# Altium schematic sheet analog.SchDoc
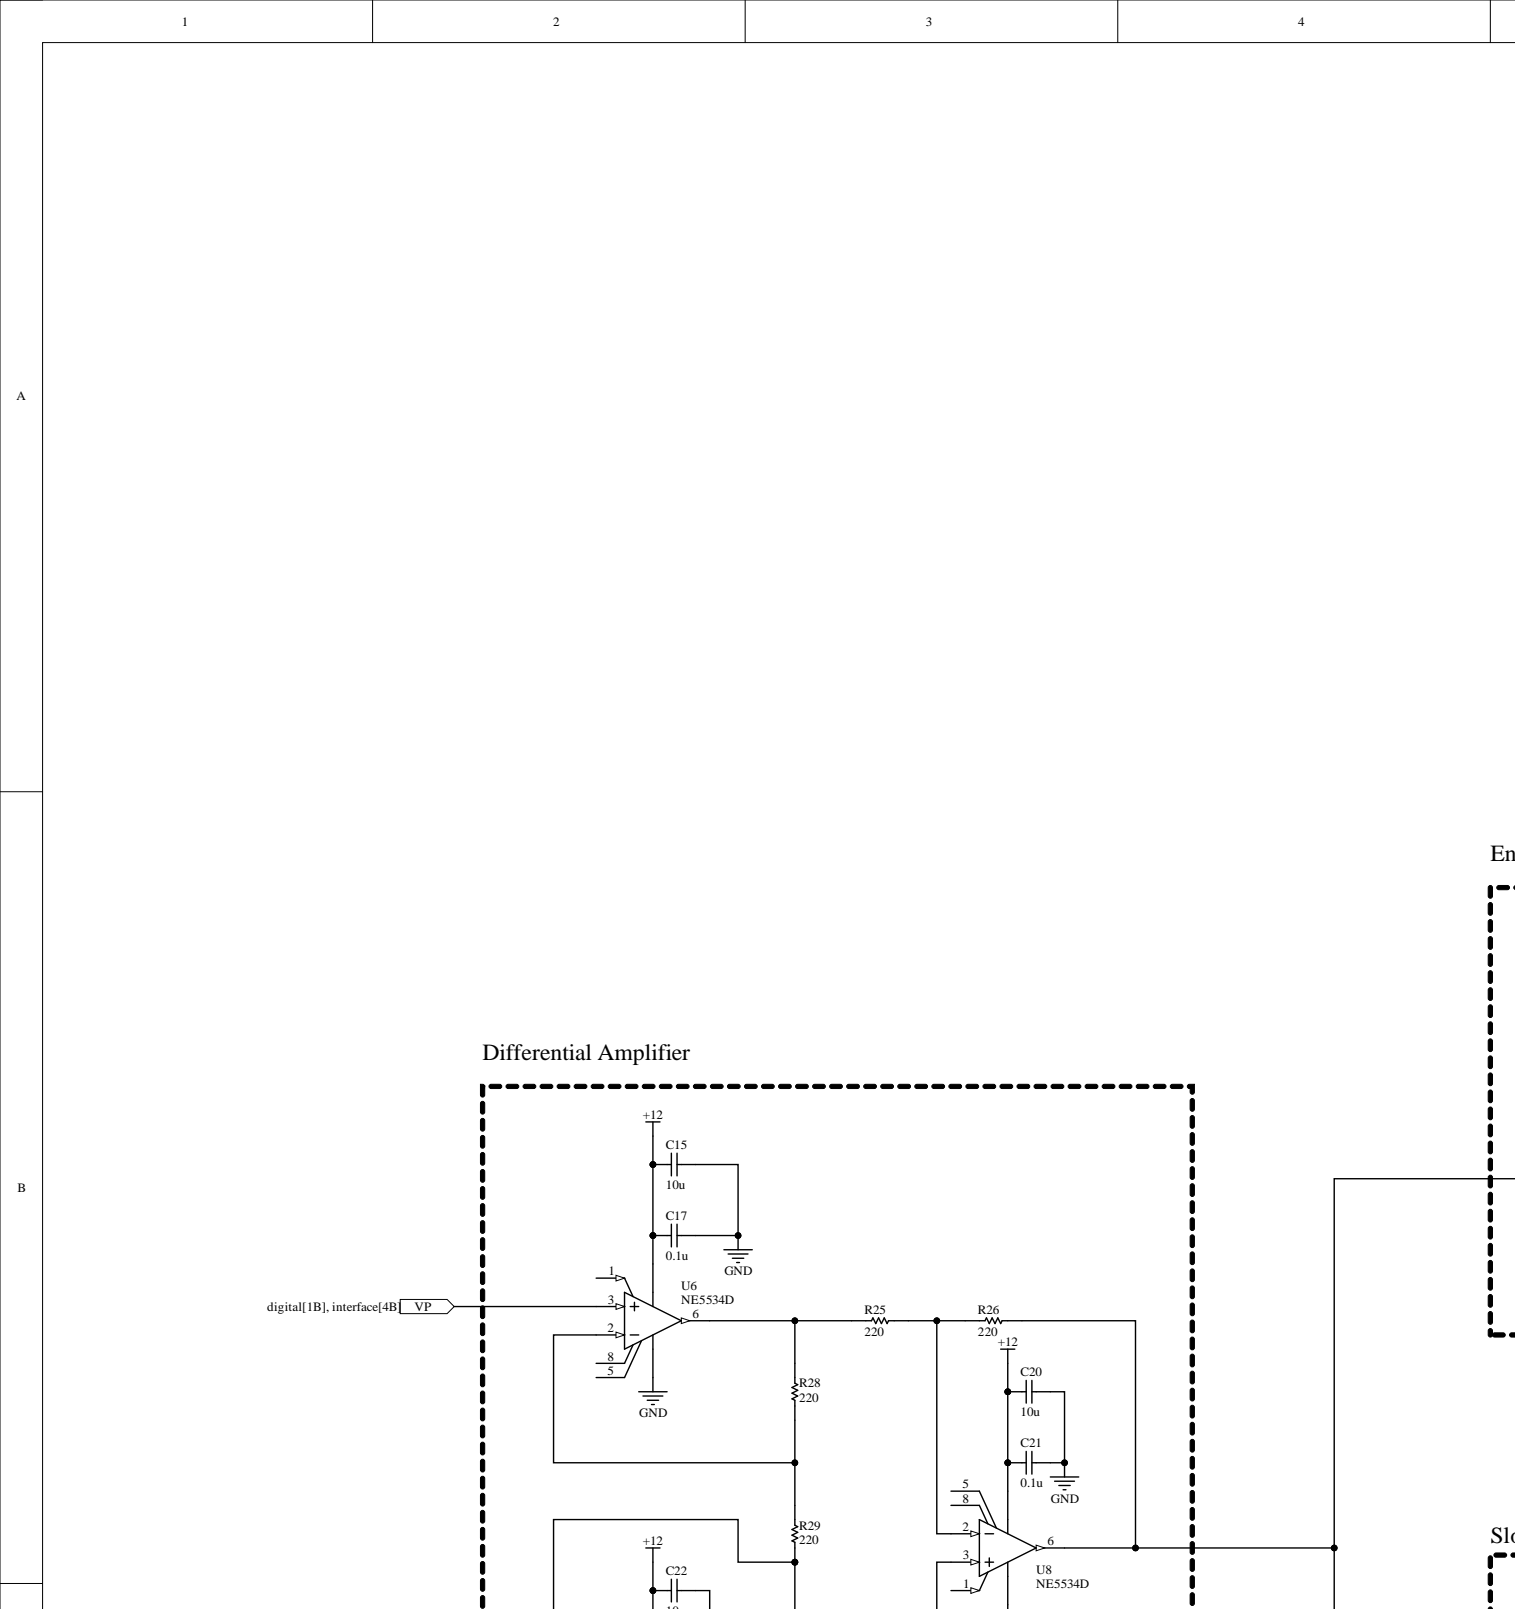
[diagram p0: analog.SchDoc, top left]
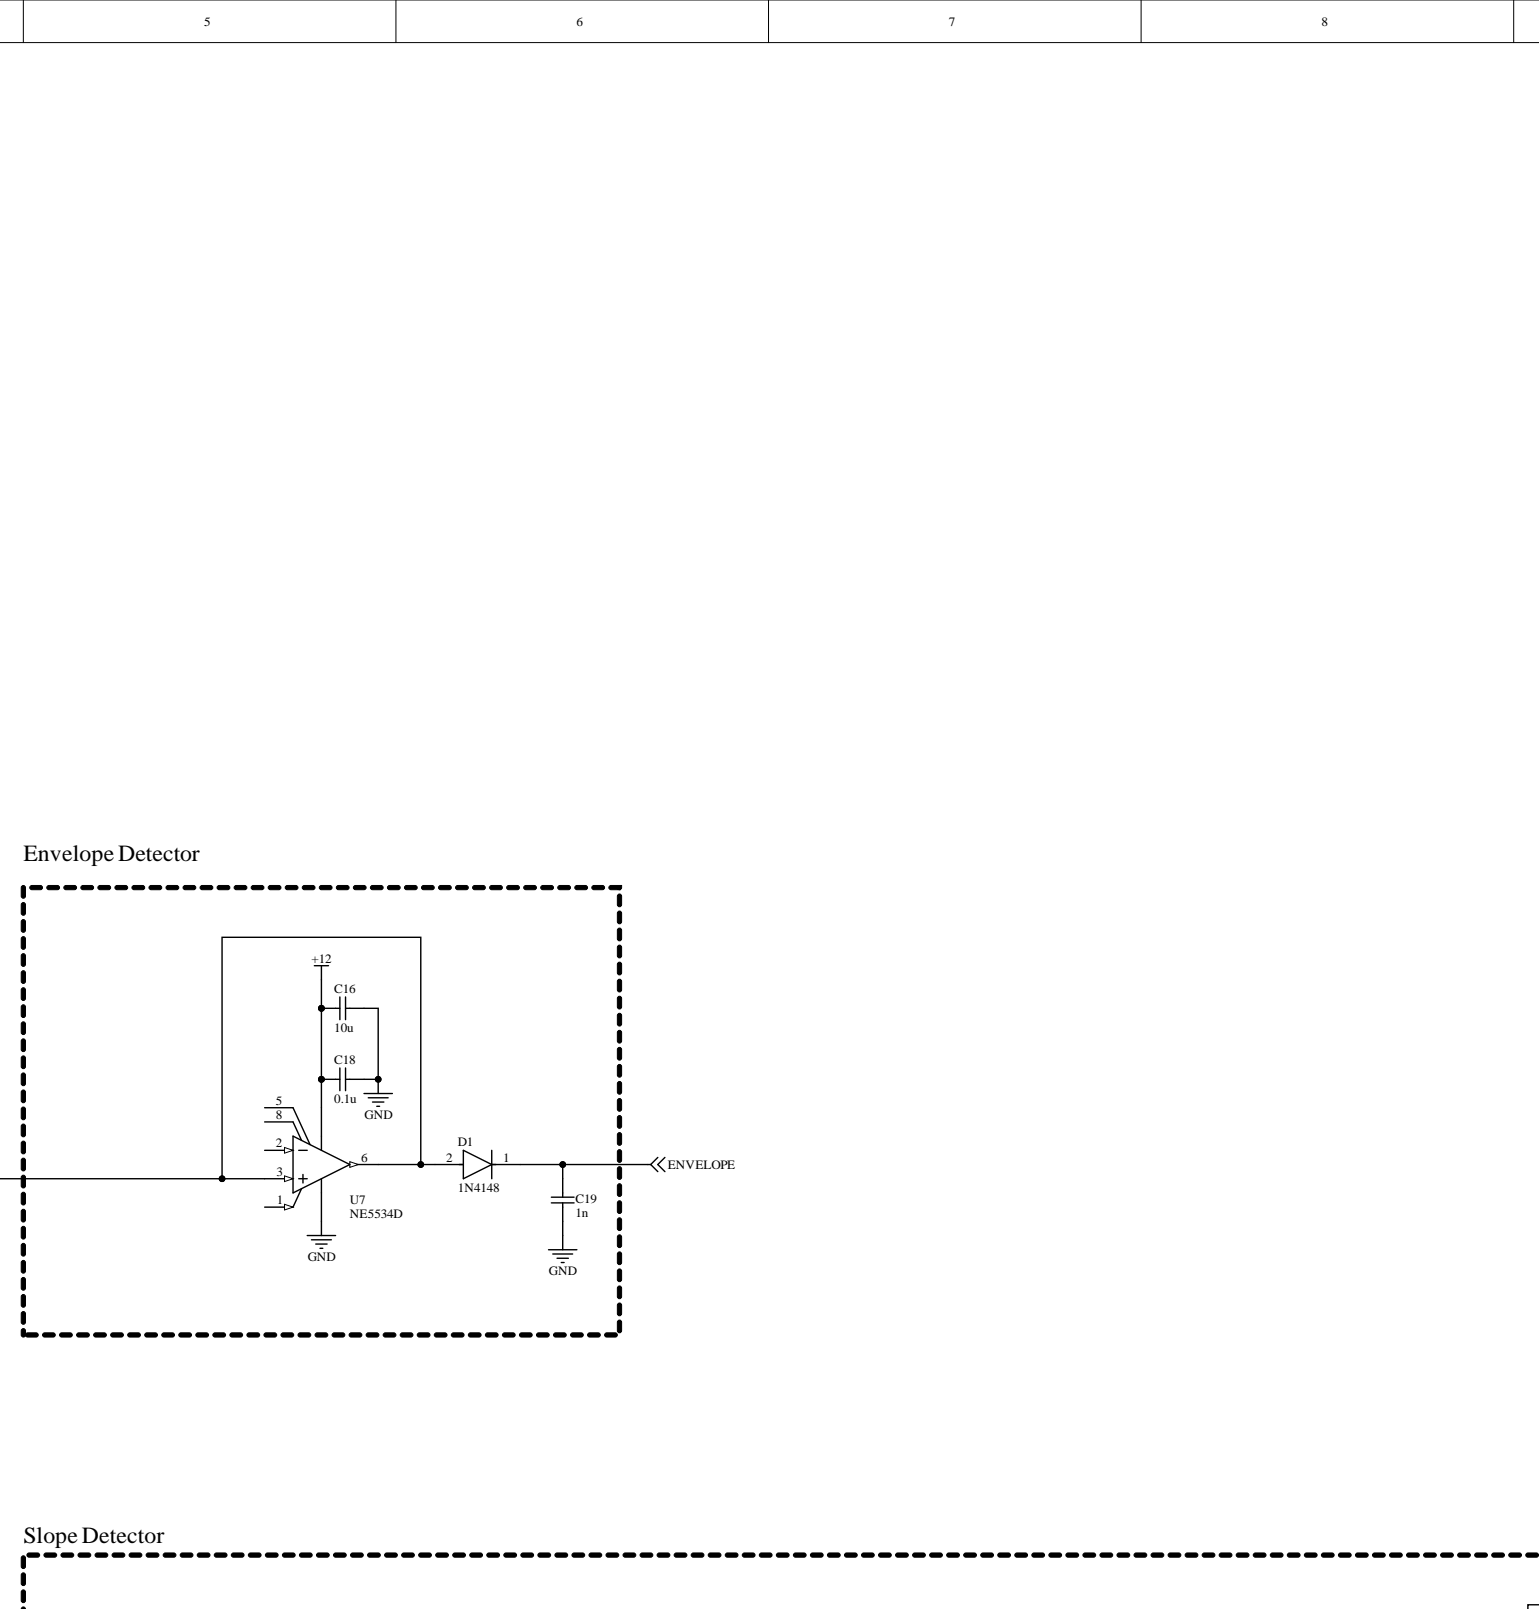
[diagram p1: analog.SchDoc, top centre]
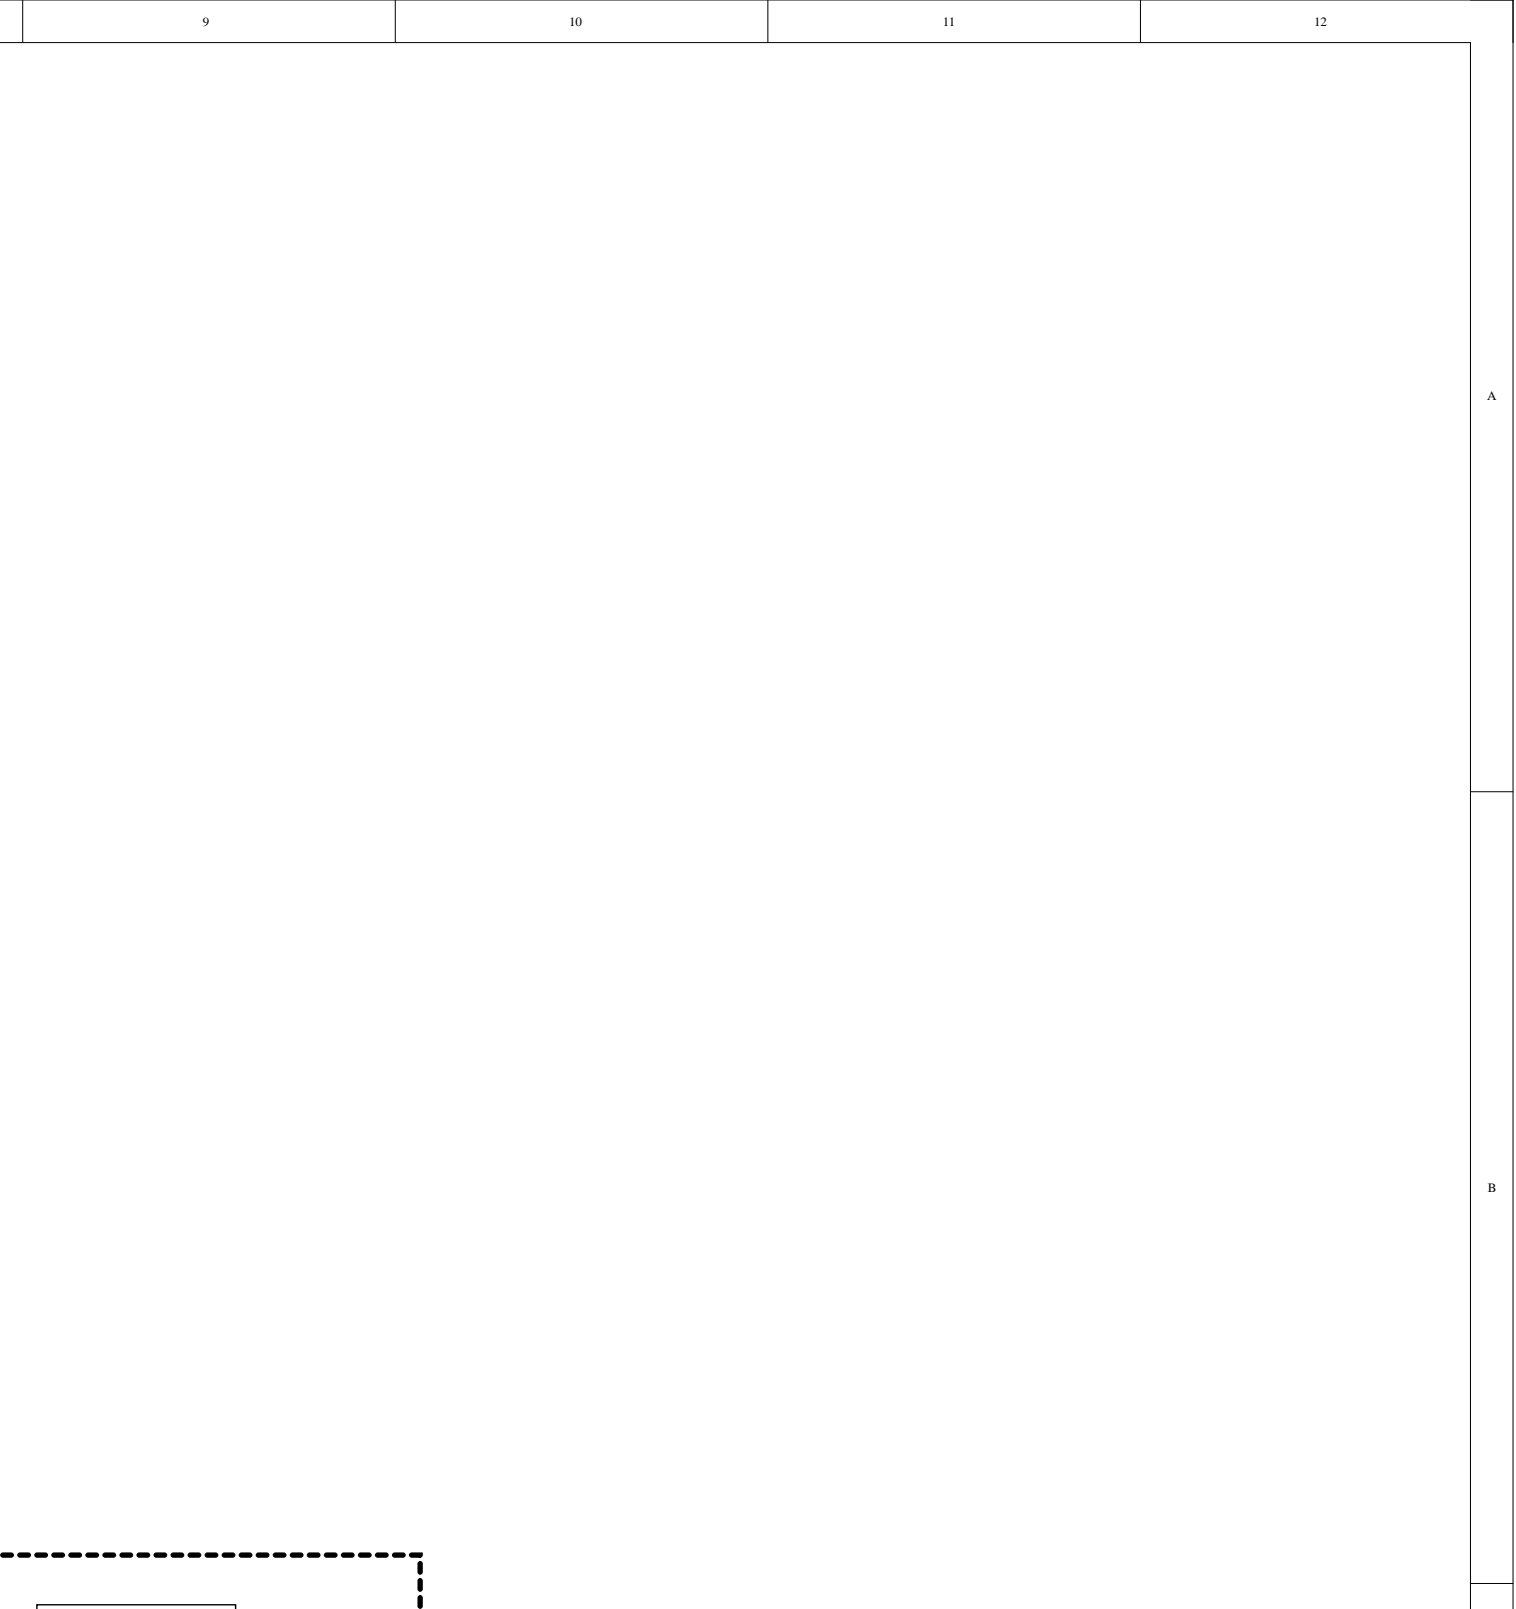
[diagram p2: analog.SchDoc, top right]
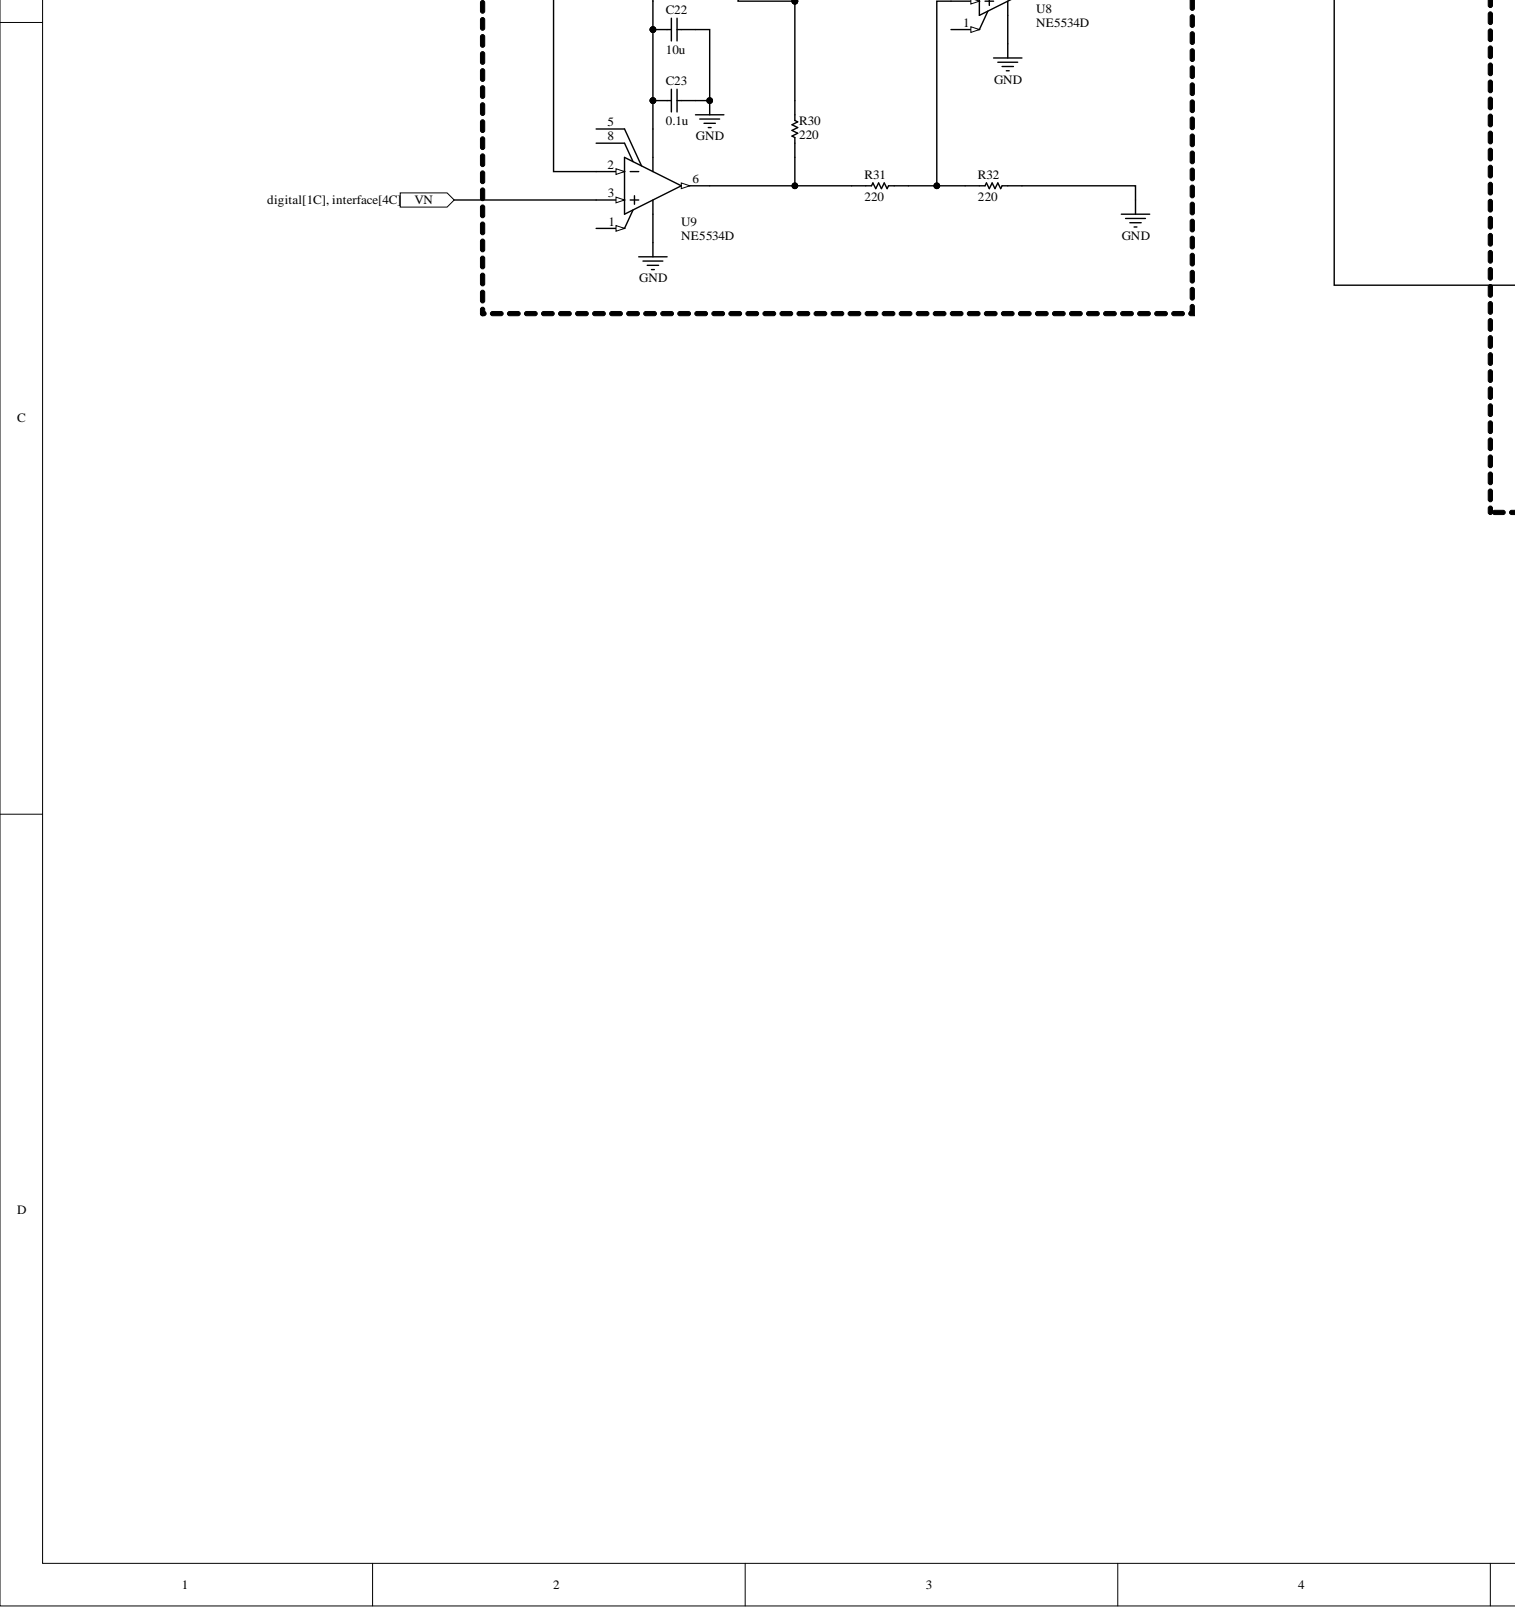
[diagram p3: analog.SchDoc, bottom left]
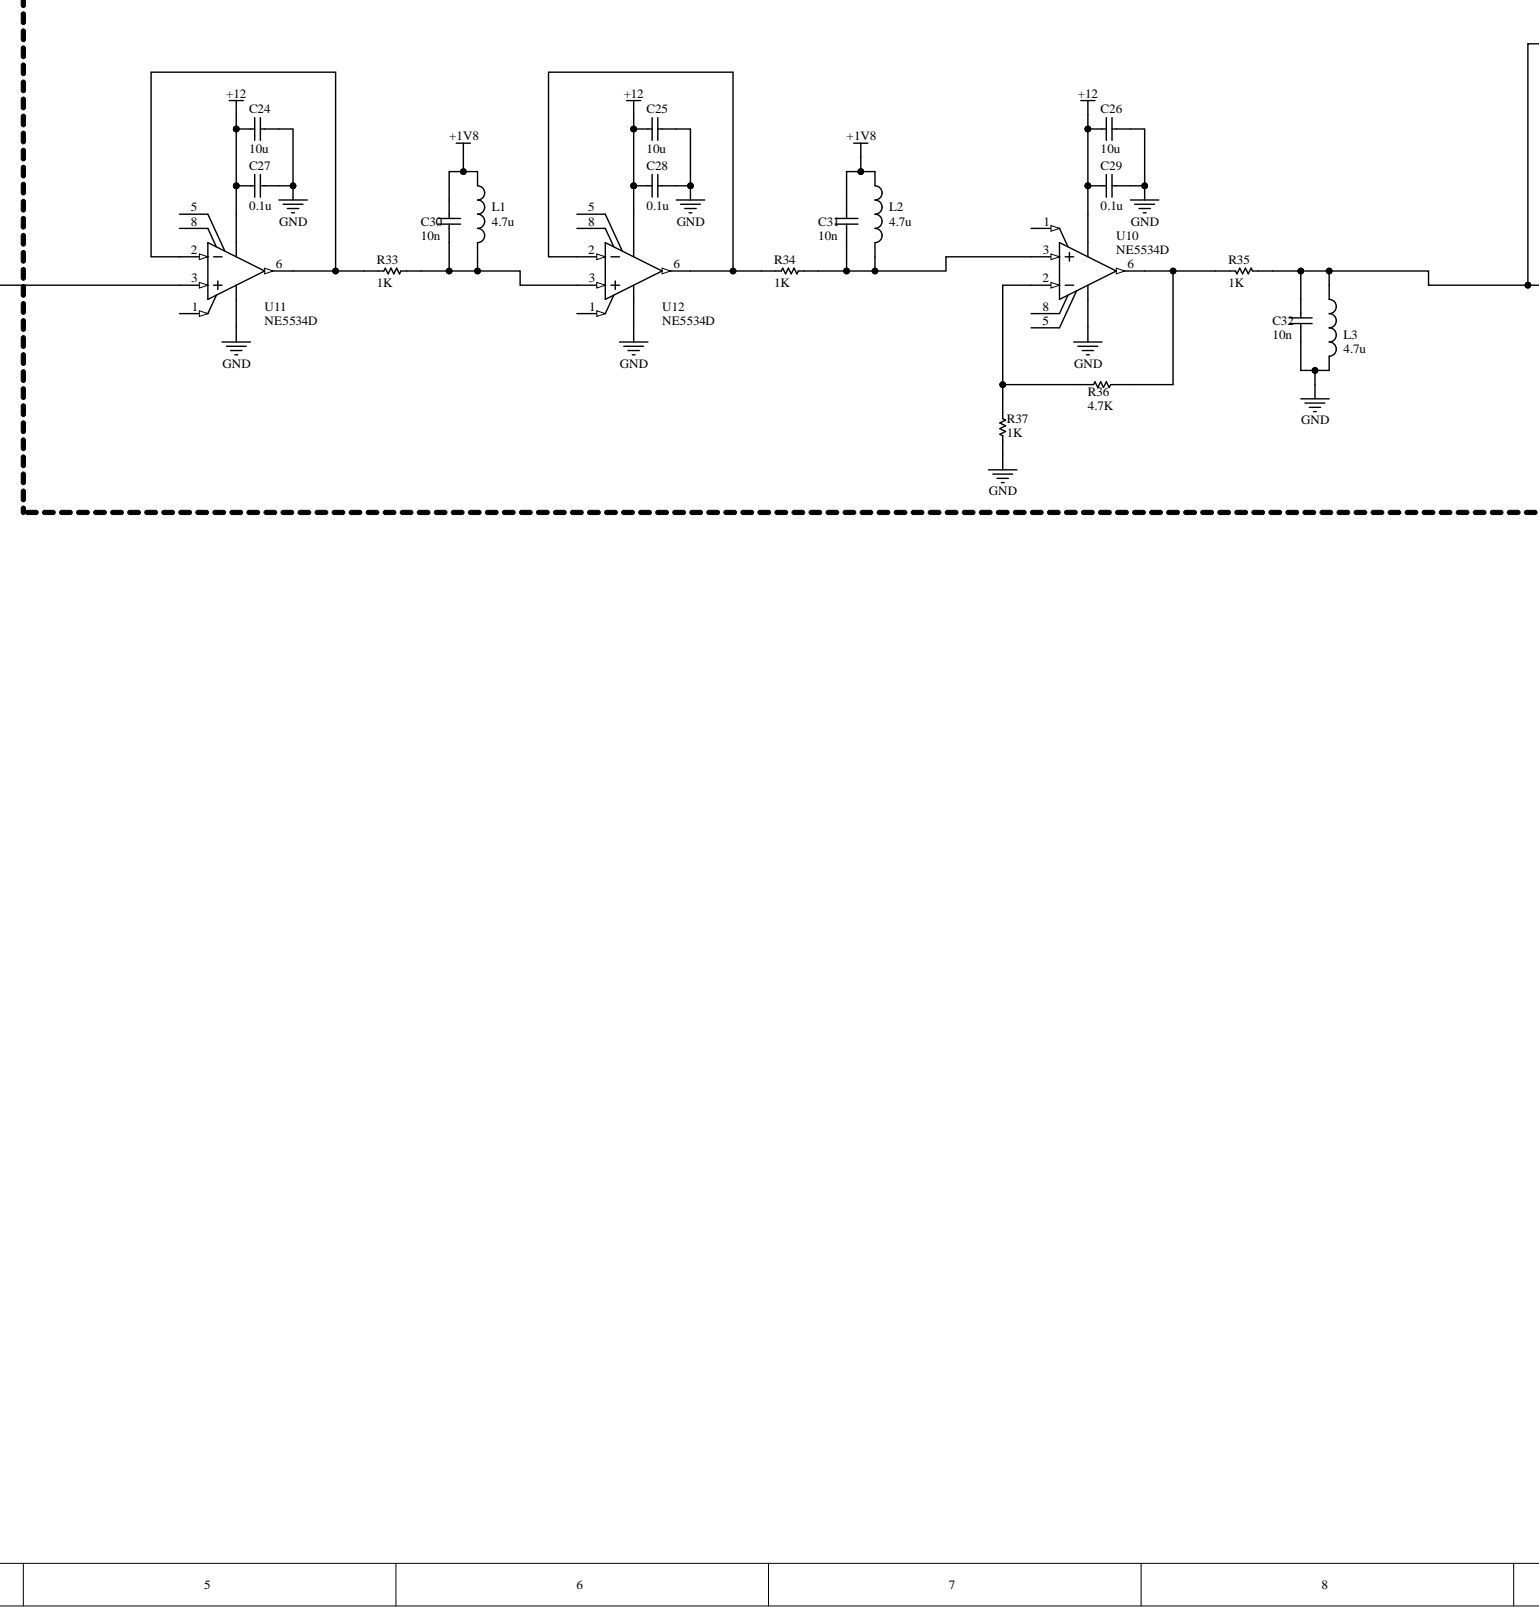
[diagram p4: analog.SchDoc, bottom centre]
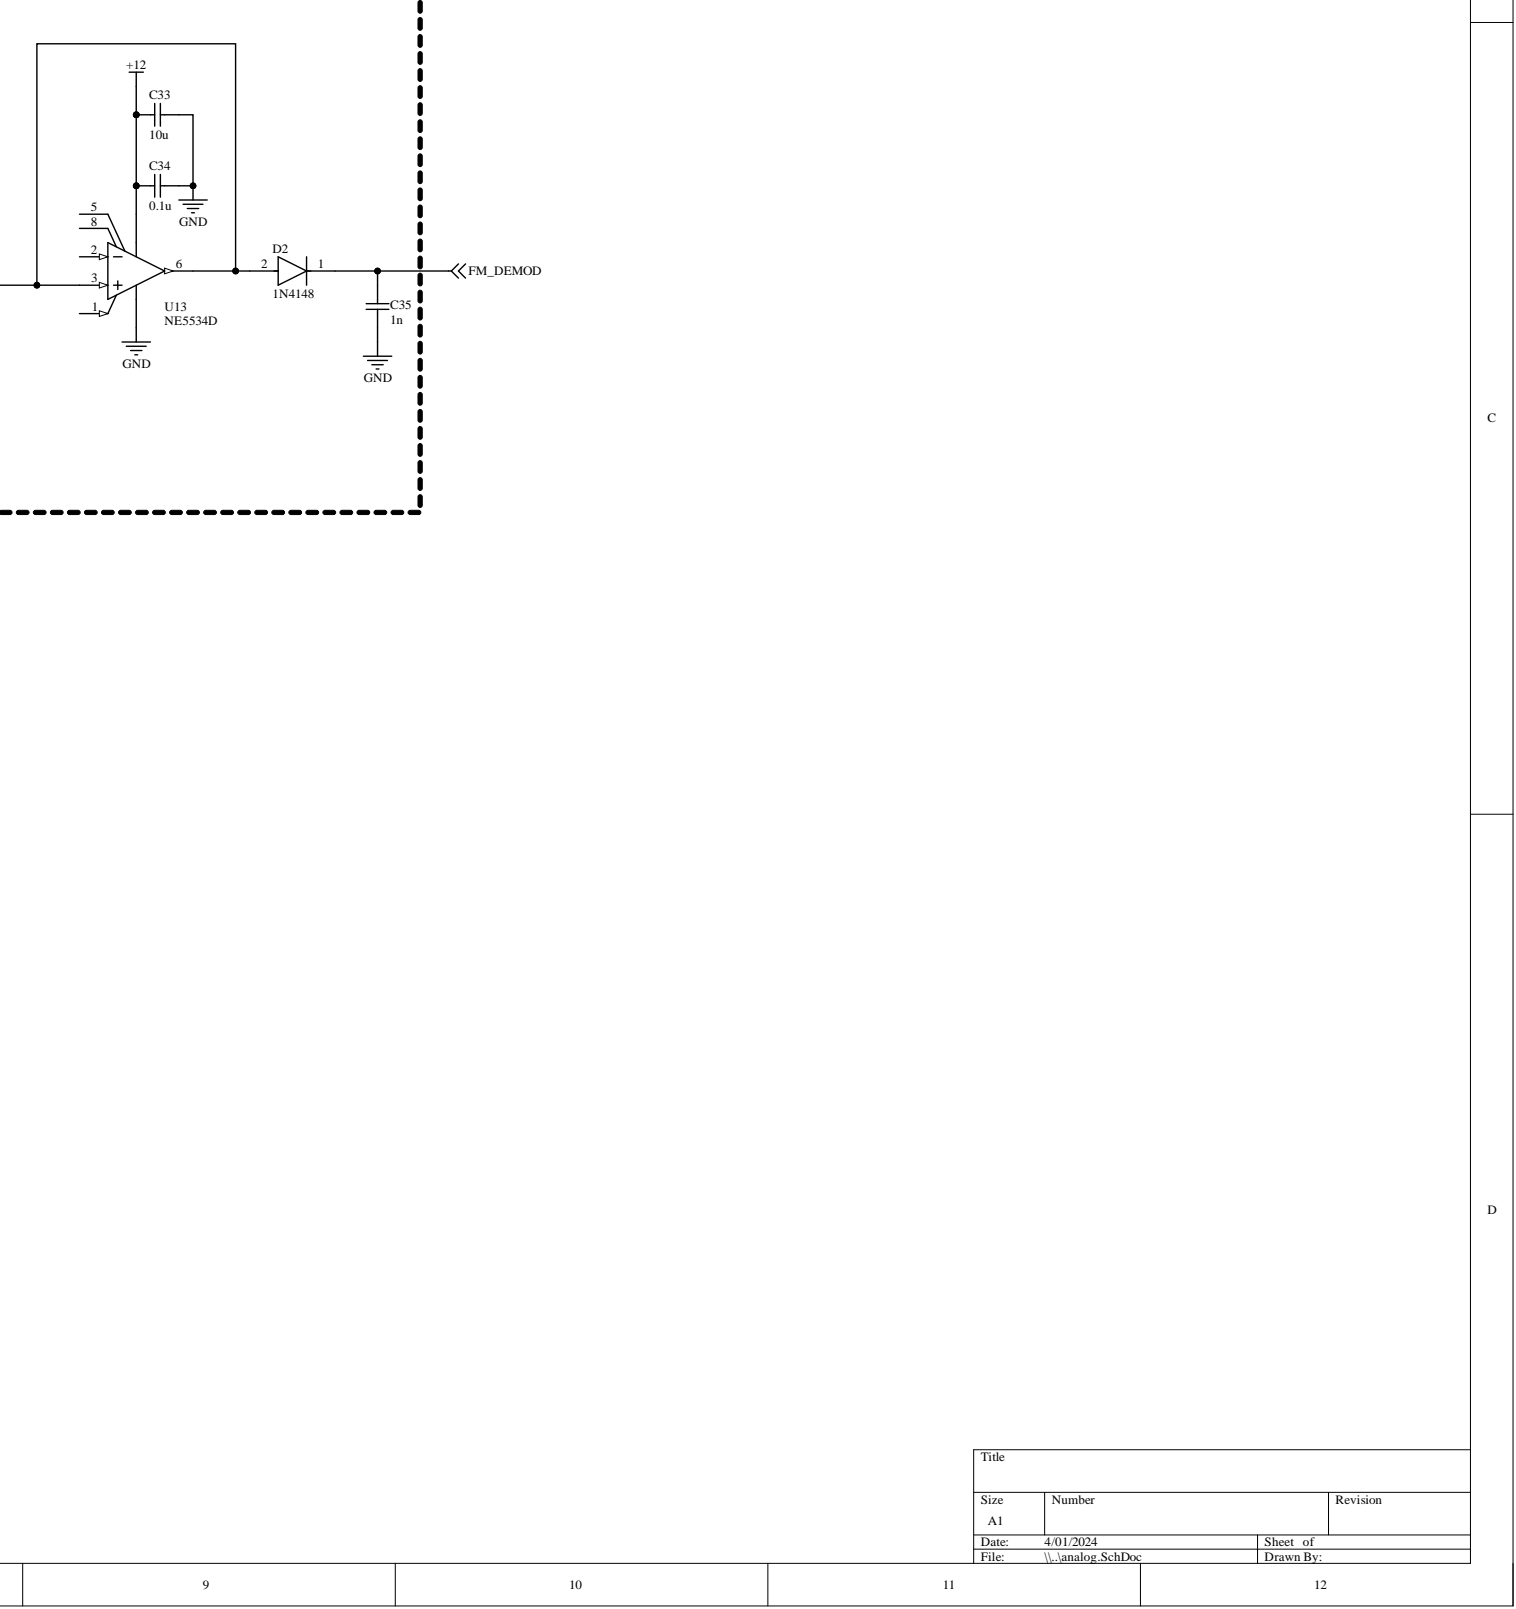
[diagram p5: analog.SchDoc, bottom right]

## Parts
C15  value 10u  (hidden comment: Capacitor)
C16  value 10u  (hidden comment: Capacitor)
C17  value 0.1u  (hidden comment: Capacitor)
C18  value 0.1u  (hidden comment: Capacitor)
C19  value 1n  (hidden comment: Capacitor)
C20  value 10u  (hidden comment: Capacitor)
C21  value 0.1u  (hidden comment: Capacitor)
C22  value 10u  (hidden comment: Capacitor)
C23  value 0.1u  (hidden comment: Capacitor)
C24  value 10u  (hidden comment: Capacitor)
C25  value 10u  (hidden comment: Capacitor)
C26  value 10u  (hidden comment: Capacitor)
C27  value 0.1u  (hidden comment: Capacitor)
C28  value 0.1u  (hidden comment: Capacitor)
C29  value 0.1u  (hidden comment: Capacitor)
C30  value 10n  (hidden comment: Capacitor)
C31  value 10n  (hidden comment: Capacitor)
C32  value 10n  (hidden comment: Capacitor)
C33  value 10u  (hidden comment: Capacitor)
C34  value 0.1u  (hidden comment: Capacitor)
C35  value 1n  (hidden comment: Capacitor)
D1  1N4148  [FP-017AG-MFG]
D2  1N4148  [FP-017AG-MFG]
L1  value 4.7u  (hidden comment: Inductor)
L2  value 4.7u  (hidden comment: Inductor)
L3  value 4.7u  (hidden comment: Inductor)
R25  value 220  (hidden comment: Resistor)
R26  value 220  (hidden comment: Resistor)
R28  value 220  (hidden comment: Resistor)
R29  value 220  (hidden comment: Resistor)
R30  value 220  (hidden comment: Resistor)
R31  value 220  (hidden comment: Resistor)
R32  value 220  (hidden comment: Resistor)
R33  value 1K  (hidden comment: Resistor)
R34  value 1K  (hidden comment: Resistor)
R35  value 1K  (hidden comment: Resistor)
R36  value 4.7K  (hidden comment: Resistor)
R37  value 1K  (hidden comment: Resistor)
U6  NE5534D  [D0008A_N]
U7  NE5534D  [D0008A_N]
U8  NE5534D  [D0008A_N]
U9  NE5534D  [D0008A_N]
U10  NE5534D  [D0008A_N]
U11  NE5534D  [D0008A_N]
U12  NE5534D  [D0008A_N]
U13  NE5534D  [D0008A_N]

## Ports
VN, VP

## Nets
+1V8: C30.2 C31.2 L1.1 L2.1
+12: C15.1 C16.1 C17.1 C18.1 C20.1 C21.1 C22.1 C23.1 C24.1 C25.1 C26.1 C27.1 C28.1 C29.1 C33.1 C34.1 U6.4 U7.7 U8.7 U9.7 U10.4 U11.7 U12.7 U13.7
ENVELOPE: C19.2 D1.1
FM_DEMOD: C35.2 D2.1
GND: C15.2 C16.2 C17.2 C18.2 C19.1 C20.2 C21.2 C22.2 C23.2 C24.2 C25.2 C26.2 C27.2 C28.2 C29.2 C32.2 C33.2 C34.2 C35.1 L3.1 R32.1 R37.2 U6.7 U7.4 U8.4 U9.4 U10.7 U11.4 U12.4 U13.4
VN: U9.3 ; port VN
VP: U6.3 ; port VP
NetC30_1: C30.1 L1.2 R33.1 U12.3
NetC31_1: C31.1 L2.2 R34.1 U10.3
NetC32_1: C32.1 D2.2 L3.2 R35.1 U13.3 U13.6
NetD1_2: D1.2 R26.1 U7.3 U7.6 U8.6 U11.3
NetR25_1: R25.1 R26.2 U8.2
NetR25_2: R25.2 R28.2 U6.6
NetR28_1: R28.1 R29.2 U6.2
NetR29_1: R29.1 R30.2 U9.2
NetR30_1: R30.1 R31.2 U9.6
NetR31_1: R31.1 R32.2 U8.3
NetR33_2: R33.2 U11.2 U11.6
NetR34_2: R34.2 U12.2 U12.6
NetR35_2: R35.2 R36.1 U10.6
NetR36_2: R36.2 R37.1 U10.2

Not connected: U6 1 5 8; U7 1 2 5 8; U8 1 5 8; U9 1 5 8; U10 1 5 8; U11 1 5 8; U12 1 5 8; U13 1 2 5 8

## Library data of the parts
C15-C35 = Capacitor: SimulationGenericComponent: True; Component Kind: Standard; Description: Capacitor; Library Name: Simulation Generic Components.IntLib; Simulation: C_Capacitor_Default
D1 D2 = CMP-07163-000046-1: DIODE GEN PURP 100V 200MA DO35; Capacitance: 4pF; Current: 4A; Element Configuration: Single; Forward Current: 300mA; Forward Voltage: 1V; Max Forward Surge Current (Ifsm): 1A; Max Power Dissipation: 500mW; Max Reverse Current: 5uA; Outside Diameter: 1.85mm; Polarity: Standard; Recovery Time: 4ns; Average Rectified Current: 200mA; Case/Package: DO-35; Component Kind: Standard; Contact Plating: Tin; Current Rating: 200mA; Diameter: 1.91mm; Height: 4.56mm; Lead Free: Lead Free; Length: 4.56mm; Library Name: Altium Content Vault; Max Operating Temperature: 175°C; Max Repetitive Reverse Voltage (Vrrm): 100V; Max Reverse Voltage (DC): 100V; Max Surge Current: 4A; Min Operating Temperature: -65°C; Mount: Through Hole; Number of Pins: 2; Output Current: 200mA; Package Quantity: 2000; Packaging: Bulk; Peak Non-Repetitive Surge Current: 4A; Power Dissipation: 500mW; Radiation Hardening: No; REACH SVHC: No SVHC; Reverse Recovery Time: 4ns; Reverse Voltage: 100V; Series: -; Voltage: 100V; Voltage Rating (DC): 100V; Weight: 0.01lb; Width: 1.91mm
L1-L3 = Inductor: SimulationGenericComponent: True; Component Kind: Standard; Description: Inductor; Library Name: Simulation Generic Components.IntLib; Simulation: L_Inductor_Default
R25 R26 R28-R37 = Resistor: SimulationGenericComponent: True; TC1: 0; Component Kind: Standard; Description: Resistor; Library Name: Simulation Generic Components.IntLib; Simulation: R_Resistor_Default; TC2: 0
U6-U13 = CMP-0017-00431-2: Low-Noise High-Speed Audio Operational Amplifier, 10 to 30 V, 0 to 70 degC, 8-pin SOIC (D8), Green (RoHS & no Sb/Br); Manufacturer: Texas Instruments; CMRR(Min)(dB): 70; GBW(Typ)(MHz): 10; IIB(Max)(pA): 1500000; Iq per channel(Max)(mA): 8; Number of Channels: 1; Slew Rate(Typ)(V/us): 13; Sub Family: General Purpose Amplifier, High Supply Voltage Amplifier (>=30V), Low Noise Amp (<=10nV/rtHz); Total Supply Voltage(Max)(+5V is 5, +/-5V is 10): 30; Vio (25C)(Max)(mV): 4; Code_JEDEC: MS-012; Component Kind: Standard; ComponentLink1Description: Manufacturer URL; ComponentLink1URL: http://www.ti.com/; ComponentLink2Description: Datasheet; ComponentLink2URL: http://www.ti.com/lit/ds/symlink/ne5534.pdf; ComponentLink3Description: Package Specification; ComponentLink3URL: http://www.ti.com/litv/pdf/msoi002j; DatasheetVersion: SLOS070C; Eco-Plan: Green (RoHS & no Sb/Br); Library Name: Altium Content Vault; Mounting Technology: Surface Mount; PackageDescription: 8-Pin Plastic Small Outline, Body 4.9 x 3.9 mm, Pitch 1.27 mm; PackageReference: D0008A; PackageVersion: 4040047-3/M, 06/2011; PartNumber: NE5534D; Total Supply Voltage(Min)(+5V is 5, +/-5V is 10): 10; Vn at 1kHz(Typ)(nV/rtHz): 4
